# Altium schematic sheet PICO_D4_SD_CARD_T3.SchDoc
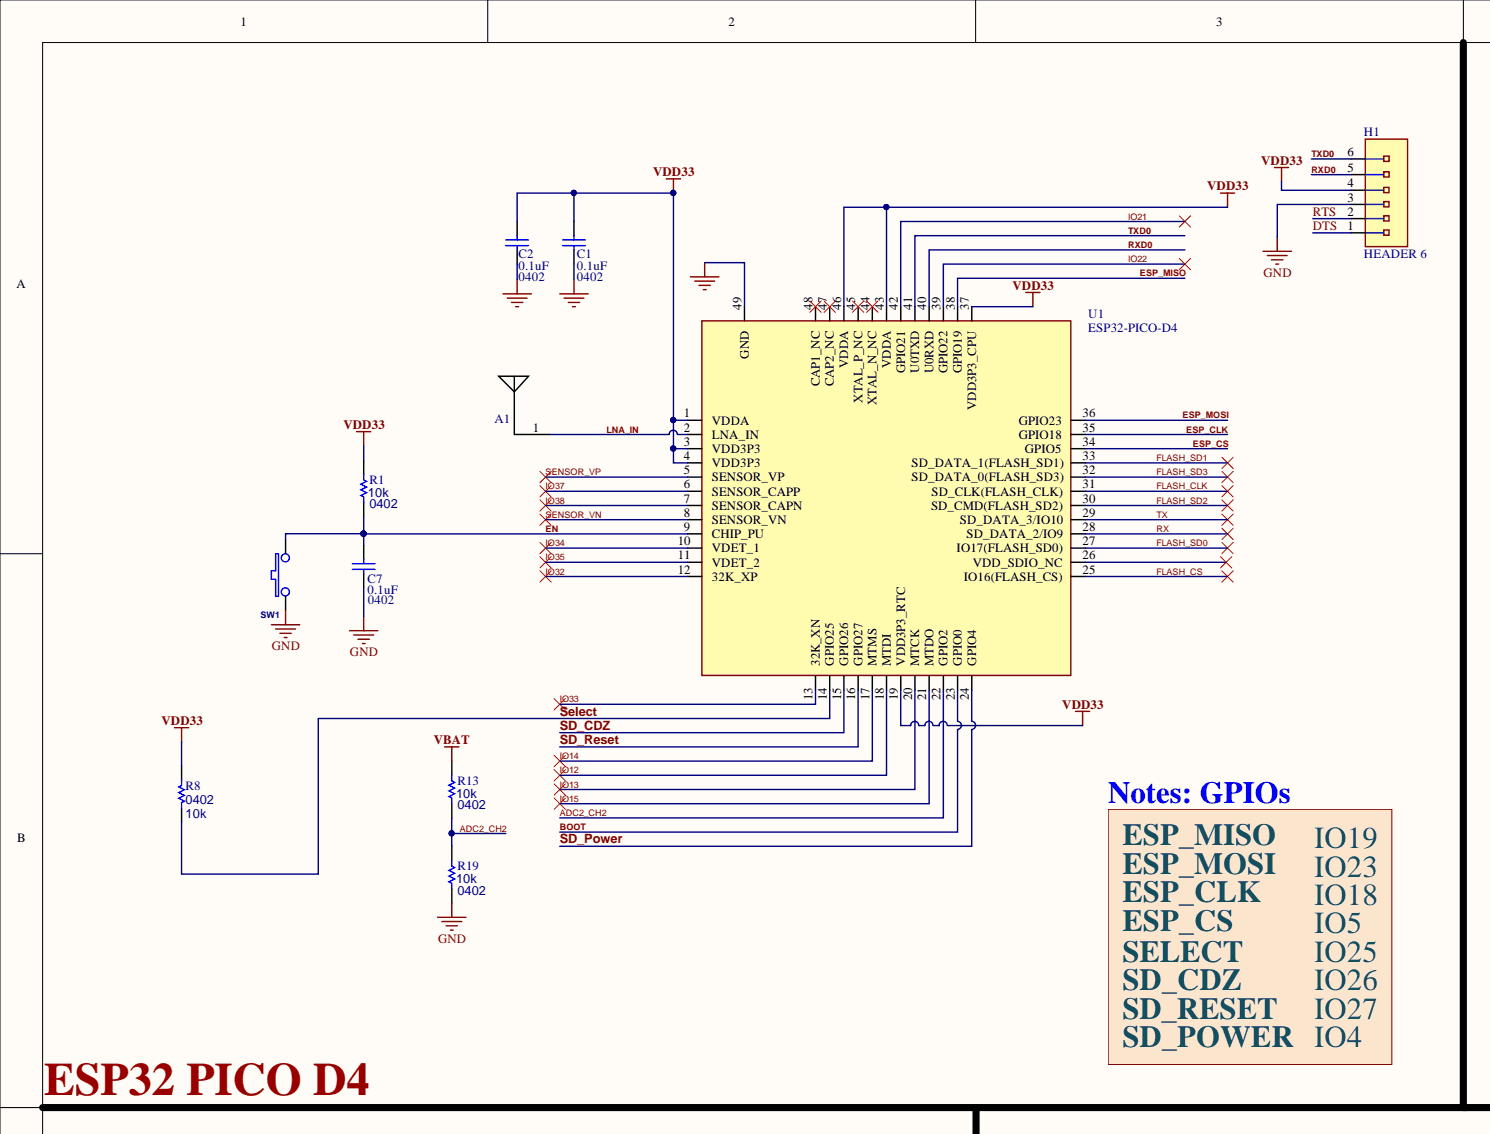
[diagram p0: PICO_D4_SD_CARD_T3.SchDoc, top left]
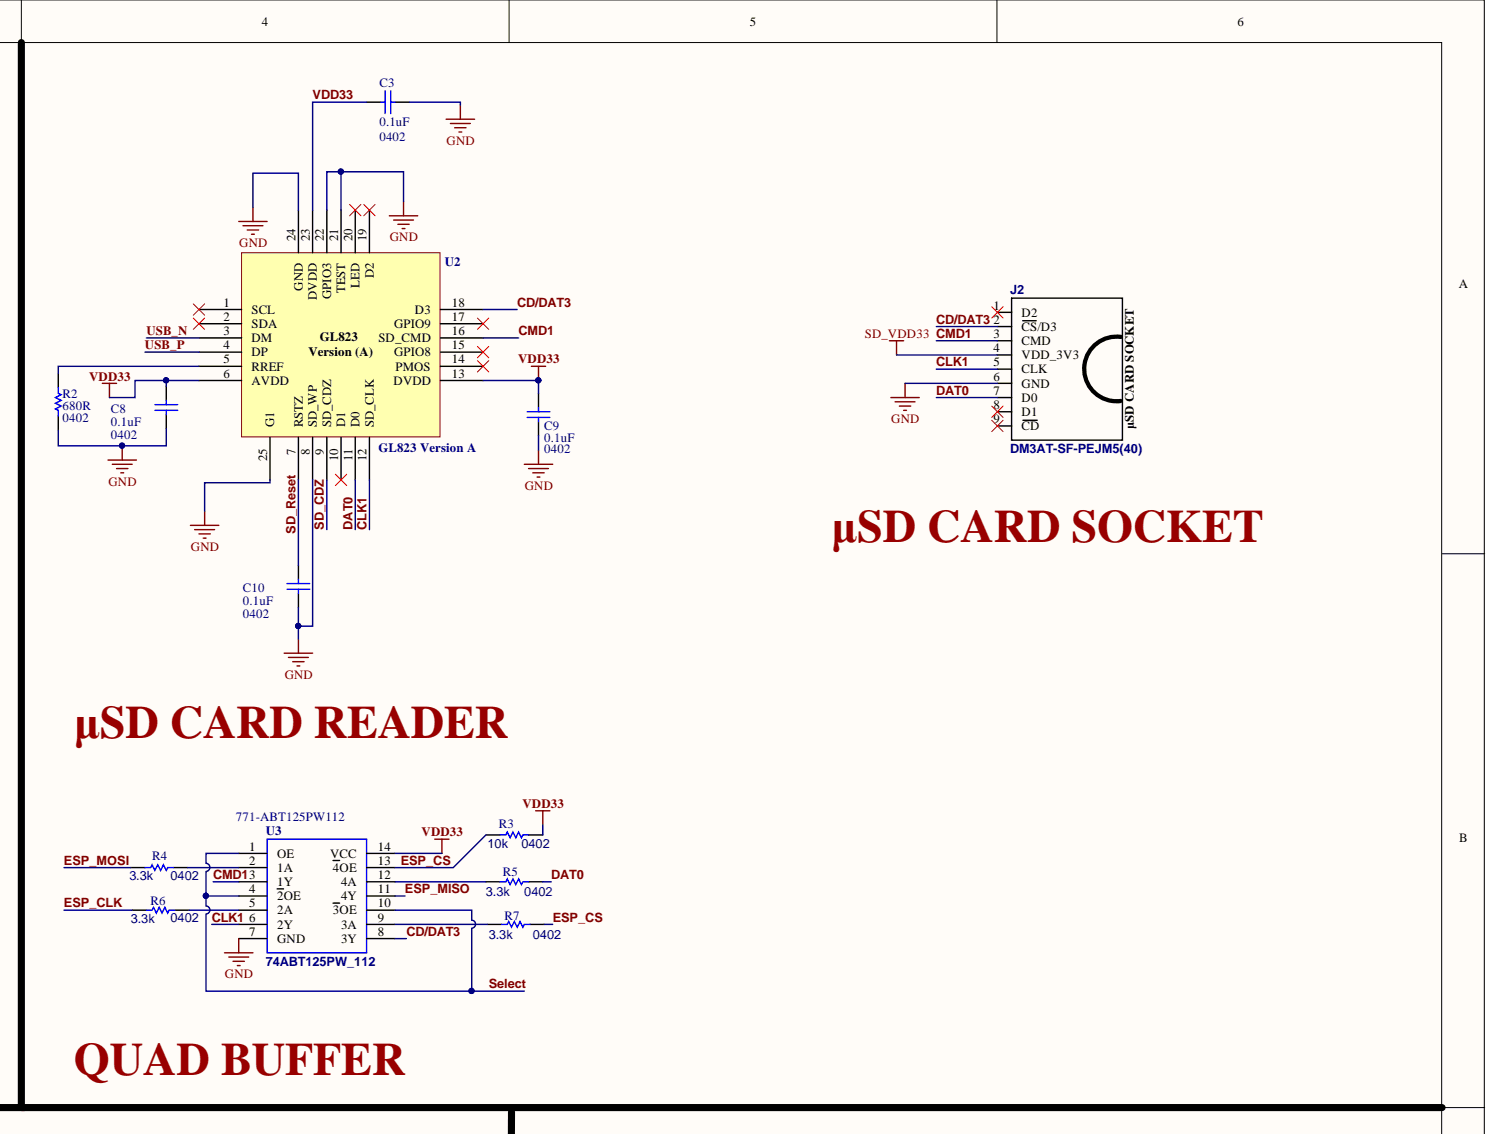
[diagram p1: PICO_D4_SD_CARD_T3.SchDoc, top right]
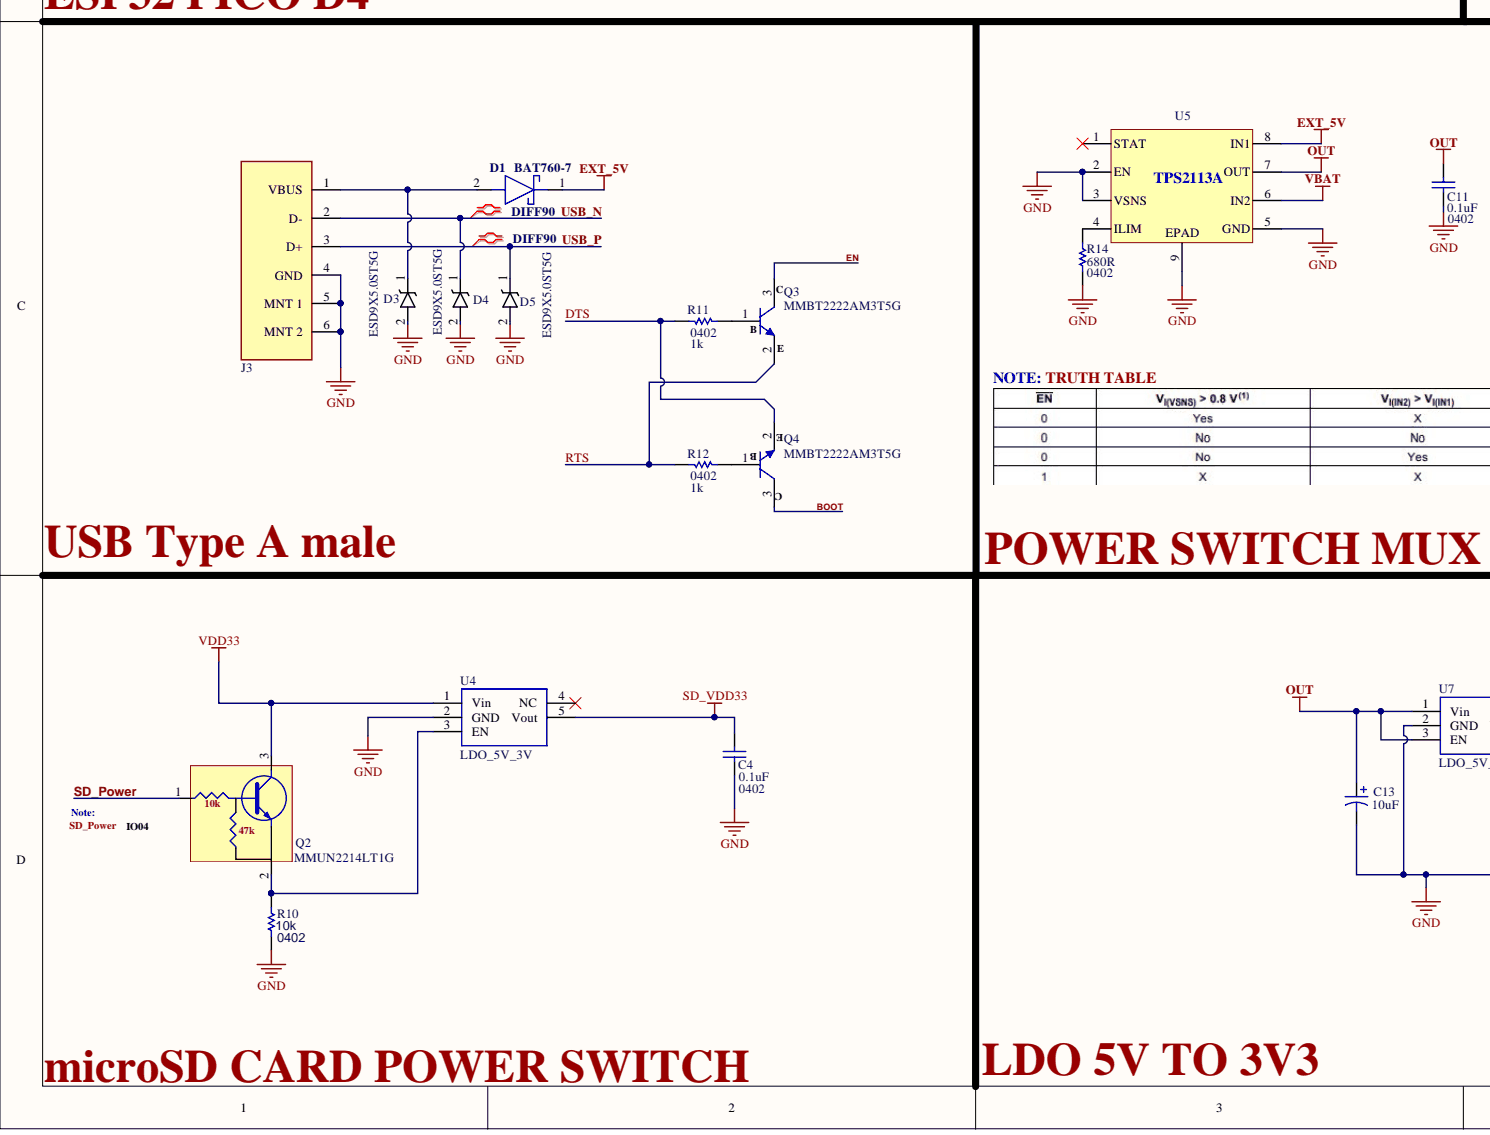
[diagram p2: PICO_D4_SD_CARD_T3.SchDoc, bottom left]
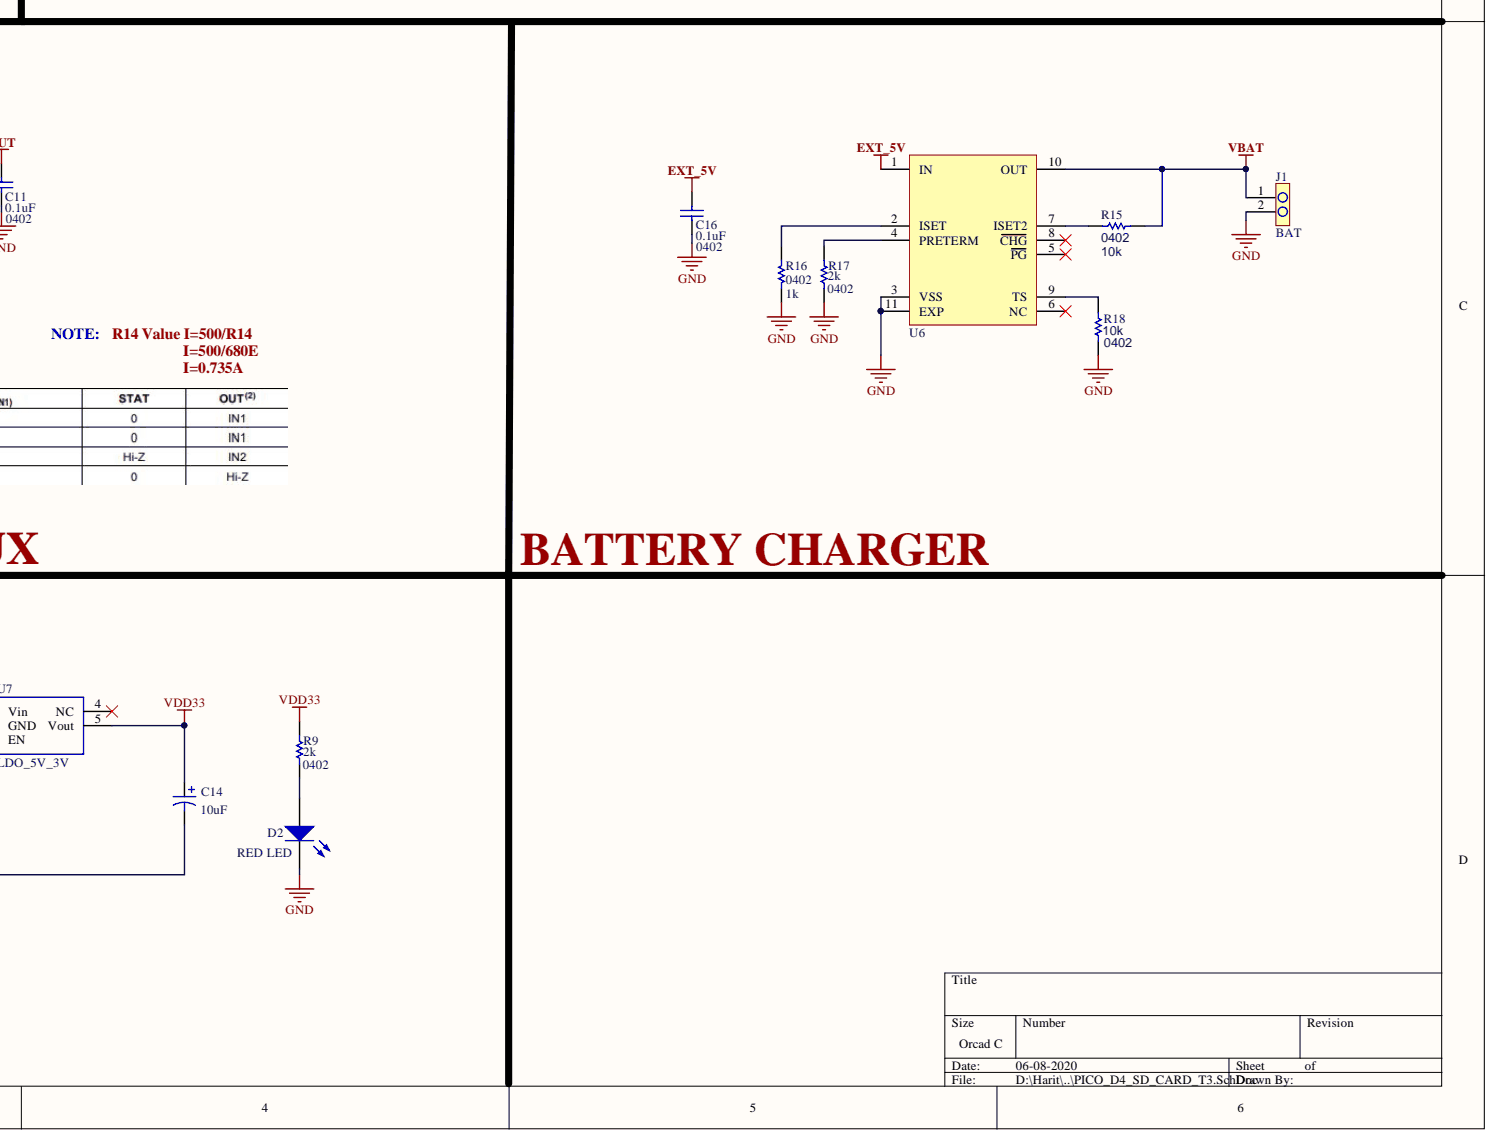
[diagram p3: PICO_D4_SD_CARD_T3.SchDoc, bottom right]

## Parts
A1  Ant  [ANT_2450AT43B100E]  (value not drawn)
C1  0.1uF  Case Code (Imperial): 0402  [CAPC0402]
C2  0.1uF  Case Code (Imperial): 0402  [CAPC0402]
C3  0.1uF  Case Code (Imperial): 0402  [CAPC0402]
C4  0.1uF  Case Code (Imperial): 0402  [CAPC0402]
C7  0.1uF  Case Code (Imperial): 0402  [CAPC0402]
C8  0.1uF  Case Code (Imperial): 0402  [CAPC0402]
C9  0.1uF  Case Code (Imperial): 0402  [CAPC0402]
C10  0.1uF  Case Code (Imperial): 0402  [CAPC0402]
C11  0.1uF  Case Code (Imperial): 0402  [CAPC0402]
C13  10uF  [CAPC0603]
C14  10uF  [CAPC0603]
C16  0.1uF  Case Code (Imperial): 0402  [CAPC0402]
D1  BAT760-7  [SOD2513X120N]
D2  RED LED  [LED_0603]
D3  ESD9X5.0ST5G  [SOD-923]
D4  ESD9X5.0ST5G  [SOD-923]
D5  ESD9X5.0ST5G  [SOD-923]
H1  HEADER 6  [HEADER_2MM]
J1  BAT  [HDR1X2H - duplicate]  (no BOM)
J2  DM3AT-SF-PEJM5(40)  [sd card connector]
J3  USB MALE  [Molex_48037-0001_0]  (value not drawn)
Q2  Manufacturer Part Number: MMUN2214LT1G  [SOT95P240X115-3N]  (hidden comment: Transistor NPN)
Q3  MMBT2222AM3T5G  [SOT-723]
Q4  MMBT2222AM3T5G  [SOT-723]
R1  value 10k  Case Code (Imperial): 0402  [resc0402]  (hidden comment: Res1)
R2  value 680R  Case Code (Imperial): 0402  [resc0402]
R3  value 10k  Case Code (Imperial): 0402  [resc0402]  (hidden comment: Res1)
R4  value 3.3k  Case/Package: 0402  [RESC0402]  (hidden comment: Res1)
R5  value 3.3k  Case/Package: 0402  [RESC0402]
R6  value 3.3k  Case/Package: 0402  [RESC0402]
R7  value 3.3k  Case/Package: 0402  [RESC0402]
R8  value 10k  Case Code (Imperial): 0402  [resc0402]  (hidden comment: Res1)
R9  value 2k  Case/Package: 0402  [resc0402]  (hidden comment: Res1)
R10  value 10k  Case/Package: 0402  [resc0402]  (hidden comment: Res1)
R11  value 1k  Case Code (Imperial): 0402  [resc0402]  (hidden comment: Res1)
R12  value 1k  Case Code (Imperial): 0402  [resc0402]  (hidden comment: Res1)
R13  value 10k  Case/Package: 0402  [resc0402]  (hidden comment: Res1)
R14  value 680R  Case Code (Imperial): 0402  [resc0402]
R15  value 10k  Case/Package: 0402  [resc0402]  (hidden comment: Res1)
R16  value 1k  Case Code (Imperial): 0402  [resc0402]  (hidden comment: Res1)
R17  value 2k  Case/Package: 0402  [resc0402]  (hidden comment: Res1)
R18  value 10k  Case/Package: 0402  [resc0402]  (hidden comment: Res1)
R19  value 10k  Case/Package: 0402  [resc0402]  (hidden comment: Res1)
SW1  SW PUSHBUTTON  [Micro_push]  (value not drawn)
U1  ESP32-PICO-D4  [ESP32_PICO_D4_2]
U2  GL823 Version A  [QFN24-4x4MM]
U3  74ABT125PW_112  Supplier Part Number 1: 771-ABT125PW112  [74ABT125PW]
U4  LDO_5V_3V  [SOT25]
U5  TPS2113ADRBR  [SON-8]  (value not drawn)
U6  BQ24040DSQR  [10-WFDFN]  (value not drawn)
U7  LDO_5V_3V  [SOT25]

## Nets
EXT_5V: C16.2 D1.1 U5.8(IN1) U6.1(IN)
GND: C1.2 C2.2 C3.1 C4.2 C7.1 C8.1 C9.1 C10.1 C11.1 C13.2 C14.2 C16.1 D2.2 D3.2 D4.2 D5.2 H1.3 J1.2 J2.6(GND) J3.4(GND) J3.5(MNT_1) J3.6(MNT_2) R2.2 R10.2 R14.2 R16.1 R17.2 R18.2 R19.2 SW1.1 U1.49(GND) U2.8(SD_WP) U2.21(TEST) U2.22(GPIO3) U2.24(GND) U2.25(G1) U3.7(GND) U4.2(GND) U5.2(EN) U5.3(VSNS) U5.5(GND) U5.9 U6.3(VSS) U6.11(EXP) U7.2(GND)
OUT: C11.2 C13.1 U5.7(OUT) U7.1(Vin) U7.3(EN)
SD_VDD33: C4.1 J2.4(VDD_3V3) U4.5(Vout)
VBAT: J1.1 R13.1 R15.2 U5.6(IN2) U6.10(OUT)
ADC2_CH2: R13.2 R19.1 U1.22(GPIO2)
BOOT: Q4.3 U1.23(GPIO0)
CD/DAT3: J2.2(CS/D3) U2.18(D3) U3.8(3Y)
CLK1: J2.5(CLK) U2.12(SD_CLK) U3.6(2Y)
CMD1: J2.3(CMD) U2.16(SD_CMD) U3.3(1Y)
DAT0: J2.7(D0) R5.1 U2.11(D0)
DTS: H1.1 Q4.2 R11.1
EN: C7.2 Q3.3 R1.2 SW1.2 U1.9(CHIP_PU)
ESP_CLK: R6.2 U1.35(GPIO18)
ESP_CS: R3.2 R7.1 U1.34(GPIO5) U3.13(4OE)
ESP_MISO: U1.38(GPIO19) U3.11(4Y)
ESP_MOSI: R4.2 U1.36(GPIO23)
FLASH_CLK: U1.31(SD_CLK(FLASH_CLK))
FLASH_CS: U1.25(IO16(FLASH_CS))
FLASH_SD0: U1.27(IO17(FLASH_SD0))
FLASH_SD1: U1.33(SD_DATA_1(FLASH_SD1))
FLASH_SD2: U1.30(SD_CMD(FLASH_SD2))
FLASH_SD3: U1.32(SD_DATA_0(FLASH_SD3))
IO12: U1.18(MTDI)
IO13: U1.20(MTCK)
IO14: U1.17(MTMS)
IO15: U1.21(MTDO)
IO21: U1.42(GPIO21)
IO22: U1.39(GPIO22)
IO32: U1.12(32K_XP)
IO33: U1.13(32K_XN)
IO34: U1.10(VDET_1)
IO35: U1.11(VDET_2)
IO37: U1.6(SENSOR_CAPP)
IO38: U1.7(SENSOR_CAPN)
LNA_IN: A1.1 U1.2(LNA_IN)
RTS: H1.2 Q3.2 R12.1
RX: U1.28(SD_DATA_2/IO9)
RXD0: H1.5 U1.40(U0RXD)
SD_CDZ: U1.15(GPIO26) U2.9(SD_CDZ)
SD_Power: Q2.1 U1.24(GPIO4)
SD_Reset: C10.2 U1.16(GPIO27) U2.7(RSTZ)
Select: R8.2 U1.14(GPIO25) U3.1(OE) U3.4(2OE) U3.10(3OE)
SENSOR_VN: U1.8(SENSOR_VN)
SENSOR_VP: U1.5(SENSOR_VP)
TX: U1.29(SD_DATA_3/IO10)
TXD0: H1.6 U1.41(U0TXD)
USB_N: D4.1 J3.2(D-) U2.3(DM)
USB_P: D5.1 J3.3(D+) U2.4(DP)
VDD33: C1.1 C2.1 C3.2 C8.2 C9.2 C14.1 H1.4 Q2.3 R1.1 R3.1 R8.1 R9.1 U1.1(VDDA) U1.3(VDD3P3) U1.4(VDD3P3) U1.19(VDD3P3_RTC) U1.37(VDD3P3_CPU) U1.43(VDDA) U1.46(VDDA) U2.6(AVDD) U2.13(DVDD) U2.23(DVDD) U3.14(VCC) U4.1(Vin) U7.5(Vout)
NetD1_2: D1.2 D3.1 J3.1(VBUS)
NetD2_1: D2.1 R9.2
NetQ2_2: Q2.2 R10.1 U4.3(EN)
NetQ3_1: Q3.1 R11.2
NetQ4_1: Q4.1 R12.2
NetR2_1: R2.1 U2.5(RREF)
NetR4_1: R4.1 U3.2(1A)
NetR5_2: R5.2 U3.12(4A)
NetR6_1: R6.1 U3.5(2A)
NetR7_2: R7.2 U3.9(3A)
NetR14_1: R14.1 U5.4(ILIM)
NetR15_1: R15.1 U6.7(ISET2)
NetR16_2: R16.2 U6.2(ISET)
NetR17_1: R17.1 U6.4(PRETERM)
NetR18_1: R18.1 U6.9(TS)

Not connected: J2 1(D2) 8(D1) 9(CD); U1 26(VDD_SDIO_NC) 44(XTAL_N_NC) 45(XTAL_P_NC) 47(CAP2_NC) 48(CAP1_NC); U2 1(SCL) 2(SDA) 10(D1) 14(PMOS) 15(GPIO8) 17(GPIO9) 19(D2) 20(LED); U4 4(NC); U5 1(STAT); U6 5(PG) 6(NC) 8(CHG); U7 4(NC)

## Library data of the parts
A1 = Ant: High Frequency Ceramic Solutions 4-Pin SMD T/R; Manufacturer: Johanson; Manufacturer Part Number: 2450AT43B100E; Frequency: 2.4GHz; Gain: -0.5dB; Impedance: 50R; Max Frequency: 2.5GHz; Max Power Dissipation: 2W; Number of Bands: 1; Return Loss: -9.5dB; Case/Package: SMD/SMT; Category: RF Antennas; Component Kind: Standard; ComponentLink1Description: https://datasheet.ciiva.com/24068/2450at43b100-242468-24068034.pdf?src-supplier=Mouser; ComponentLink1URL: https://datasheet.ciiva.com/24068/2450at43b100-242468-24068034.pdf?src-supplier=Mouser; ComponentLink2Description: https://datasheet.ciiva.com/2792/jti-antenna-2450at43b100-09042008-2792593.pdf?src-supplier=Digi-Key; ComponentLink2URL: https://datasheet.ciiva.com/2792/jti-antenna-2450at43b100-09042008-2792593.pdf?src-supplier=Digi-Key; ComponentLink3Description: https://datasheet.ciiva.com/7633/1860765-7633973.pdf?src-supplier=Element14; ComponentLink3URL: https://datasheet.ciiva.com/7633/1860765-7633973.pdf?src-supplier=Element14; Contact Plating: Tin; Height: 0.079inch; Length: 7mm; Library Name: CUSTOM TNY290PG.SchLib; Mount: Surface Mount; Number of Pins: 4; Package Quantity: 1; Packaging: Tape and Reel; Power Rating: 2W; Pricing 1: 1=0.71000, 10=0.62400, 25=0.58600, 100=0.47820, 250=0.44416, 500=0.37800 (USD); Radiation Hardening: No; REACH SVHC: Unknown; RoHS: Yes; Stock 1: 63050; Supplier 1: Digi-Key; Supplier Part Number 1: 712-1010-1-ND; Termination: Solder; Width: 2mm
C1-C4 C7-C11 C16 = Cap: Ceramic Capacitor,100nF,16V,0402; Manufacturer: TDK; Manufacturer Part Number: C1608X7R1C104K; Mfr.: C0805C104K1RECAUTO; Dielectric: X7R; Tolerance: 10%; Capacitance: 100nF; Case Code (Metric): 1608; Case/Package: 0402; Component Kind: Standard; ComponentLink1Description: https://media.digikey.com/pdf/Data%20Sheets/TDK%20PDFs/C_Series_GeneralAppl_Spec_rev_0.3_201012.pdf?src-supplier=Digi-Key; ComponentLink1URL: https://media.digikey.com/pdf/Data%20Sheets/TDK%20PDFs/C_Series_GeneralAppl_Spec_rev_0.3_201012.pdf?src-supplier=Digi-Key; ComponentLink2Description: https://media.digikey.com/pdf/Data%20Sheets/TDK%20PDFs/C_Series_GeneralAppl_B11.pdf?src-supplier=Digi-Key; ComponentLink2URL: https://media.digikey.com/pdf/Data%20Sheets/TDK%20PDFs/C_Series_GeneralAppl_B11.pdf?src-supplier=Digi-Key; ComponentLink3Description: https://www.verical.com/server-webapp/html/getDatasheet?partID=169336&src-supplier=Verical; ComponentLink3URL: https://www.verical.com/server-webapp/html/getDatasheet?partID=169336&src-supplier=Verical; ComponentLink4Description: http://www.tdk.co.jp/tefe02/e412_c.pdf?src-supplier=Future+Electronics; ComponentLink4URL: http://www.tdk.co.jp/tefe02/e412_c.pdf?src-supplier=Future+Electronics; ComponentLink5Description: http://www.farnell.com/datasheets/1571323.pdf?src-supplier=Element14; ComponentLink5URL: http://www.farnell.com/datasheets/1571323.pdf?src-supplier=Element14; Composition: Ceramic; Depth: 0.8mm; Dielectric Material: Ceramic; Height: 0.8mm; Lead Free: Lead Free; Length: 1.6mm; Library Name: Miscellaneous Devices.IntLib; Max Operating Temperature: 125°C; Min Operating Temperature: -55°C; Mount: Surface Mount; Number of Pins: 2; Package Quantity: 1; Packaging: Tape and Reel; Radiation Hardening: No; Stock 1: 0; Supplier 1: Digi-Key; Supplier Part Number 1: 445-1317-6-ND; Termination: SMD/SMT; Thickness: 0.037inch; Value: 0.1uF; Voltage Rating: 16V; Voltage Rating (DC): 16V; Width: 0.8mm
C13 C14 = Cap Pol2: Ceramic Capacitor,10uF,16V,0603; Mfr. No: 0603C106KAT2A; Case/Package: 0603; Component Kind: Standard; Library Name: Miscellaneous Devices.SchLib; Value: 10uF
D1 = BAT760-7: Schottky Diodes & Rectifiers 1A 30V LF1A, 2-Pin SOD-323; Case/Package: SOD-323; Component Kind: Standard; Library Name: CUSTOM TNY290PG.SchLib; Manufacturer Part Number: BAT760-7
D2 = LED1: LED, RED,SMD, 0603; Case/Package: 0603; Component Kind: Standard; Library Name: Miscellaneous Devices.SchLib; Manufacturer Part Number: LED, RED,SMD, 0603
D3-D5 = ESD9X5.0ST5G: 12.3V Clamp 8.7A (8/20µs) Ipp Tvs Diode Surface Mount SOD-923; Manufacturer: ON Semiconductor; Breakdown Voltage: 6.2V; Capacitance: 65pF; Direction: Unidirectional; Element Configuration: Single; ESD Protection: Yes; Leakage Current: 1uA; Max Power Dissipation: 150mW; Number of Channels: 1; Operating Supply Voltage: 5V; Peak Pulse Power: 107W; Power Line Protection: No; Test Current: 1mA; Case/Package: SOD-923-2; Category: ESD and Circuit Protection ICs; Clamping Voltage: 12.3V; Component Kind: Standard; ComponentLink1Description: https://datasheet.ciiva.com/30478/0900766b812769b5-30478676.pdf?src-supplier=RSComponents; ComponentLink1URL: https://datasheet.ciiva.com/30478/0900766b812769b5-30478676.pdf?src-supplier=RSComponents; ComponentLink2Description: https://datasheet.ciiva.com/25958/esd9x3-3st5g-d-25958458.pdf?src-supplier=Avnet+Europe; ComponentLink2URL: https://datasheet.ciiva.com/25958/esd9x3-3st5g-d-25958458.pdf?src-supplier=Avnet+Europe; ComponentLink3Description: https://datasheet.ciiva.com/1219/1748783-1219324.pdf?src-supplier=Newark; ComponentLink3URL: https://datasheet.ciiva.com/1219/1748783-1219324.pdf?src-supplier=Newark; ComponentLink4Description: https://datasheet.ciiva.com/3304/esd9x3-3st5g-d-3304012.pdf?src-supplier=Avnet; ComponentLink4URL: https://datasheet.ciiva.com/3304/esd9x3-3st5g-d-3304012.pdf?src-supplier=Avnet; ComponentLink5Description: https://datasheet.ciiva.com/339/esd9x3-3st5g-d-339709.pdf?src-supplier=Digi-Key; ComponentLink5URL: https://datasheet.ciiva.com/339/esd9x3-3st5g-d-339709.pdf?src-supplier=Digi-Key; ComponentLink6Description: https://datasheet.ciiva.com/16215/esd9x3-3st5g-d-16215149.pdf?src-supplier=Future+Electronics; ComponentLink6URL: https://datasheet.ciiva.com/16215/esd9x3-3st5g-d-16215149.pdf?src-supplier=Future+Electronics; ComponentLink7Description: https://datasheet.ciiva.com/339/esd9x3-3st5g-d-339710.pdf?src-supplier=Digi-Key; ComponentLink7URL: https://datasheet.ciiva.com/339/esd9x3-3st5g-d-339710.pdf?src-supplier=Digi-Key; ComponentLink8Description: https://datasheet.ciiva.com/339/esd9x3-3st5g-d-339711.pdf?src-supplier=Digi-Key; ComponentLink8URL: https://datasheet.ciiva.com/339/esd9x3-3st5g-d-339711.pdf?src-supplier=Digi-Key; Composition: Zener; Contact Plating: Tin,Matte; Depth: 0.6mm; Halogen Free: Halogen Free; Height: 0.4mm; Lead Free: Lead Free; Length: 0.8mm; Library Name: CUSTOM TNY290PG.SchLib; Manufacturer Part Number: ESD9X5.0ST5G; Max Breakdown Voltage: 6.2V; Max Operating Temperature: 150°C; Max Reverse Leakage Current: 1uA; Max Surge Current: 8.7A; Min Breakdown Voltage: 6.2V; Min Operating Temperature: -55°C; Number of Elements: 1; Number of Lines: 1; Number of Pins: 2; Number of Unidirectional Channels: 1; Package Quantity: 1; Packaging: Tape and Reel; Peak Pulse Current: 8.7A; Polarity: Unidirectional; Pricing 1: 1=0.14000, 10=0.12700, 25=0.12120, 100=0.05340, 250=0.05120, 500=0.04190, 1000=0.02910, 2500=0.02542 (USD); Radiation Hardening: No; REACH SVHC: No SVHC; Reverse Breakdown Voltage: 6.2V; Reverse Standoff Voltage: 5V; RoHS: Yes; Stock 1: 835133; Supplier 1: Digi-Key; Supplier Part Number 1: ESD9X5.0ST5GOSCT-ND; Termination: SMD/SMT; Width: 0.65mm; Working Voltage: 5V
H1 = HEADER 6: Header, 6-Pin,2MM; Manufacturer Part Number: 2mm PITCH; Case/Package: TH; Component Kind: Standard; Library Name: CUSTOM TNY290PG.SchLib
J1 = Header 2 pin: Library Name: CUSTOM TNY290PG.SchLib
J2 = DM3AT-SF-PEJM5(40): Micro SD Memory Card Socket; Manufacturer_Name: Hirose; Allied_Number: R1050628; Allied Price/Stock: http://www.alliedelec.com/hirose-electric-dm3at-sf-pejm5-40-/R1050628/; Component Kind: Standard; Datasheet Link: http://uk.rs-online.com/web/p/products/7079520; Library Name: CUSTOM TNY290PG.SchLib; Manufacturer Part Number: DM3AT-SF-PEJM5(40); Mouser Part Number: 798-DM3AT-SF-PEJM540; Mouser Price/Stock: https://www.mouser.com/Search/Refine.aspx?Keyword=798-DM3AT-SF-PEJM540; RS Part Number: 7079520P; RS Price/Stock: http://uk.rs-online.com/web/p/products/7079520P
J3 = USB MALE: USB A-type Plug Male PCB R/A; Manufacturer Part Number: USB Type A male; Case/Package: TH; Component Kind: Standard; Library Name: CUSTOM TNY290PG.SchLib
Q2 = Transistor NPN: Bipolar Transistors - Pre-Biased 100mA 50V BRT NPN; Manufacturer: ON Semiconductor; Collector Emitter Saturation Voltage: 250mV; Element Configuration: Single; hFE Min: 80; Max Power Dissipation: 246mW; Case/Package: SOT-23-3; Collector Emitter Breakdown Voltage: 50V; Collector Emitter Voltage (VCEO): 50V; Component Kind: Standard; ComponentLink1Description: http://www.onsemi.ru.com/pub/Collateral/DTC114Y-D.PDF?src-supplier=Avnet+Europe; ComponentLink1URL: http://www.onsemi.ru.com/pub/Collateral/DTC114Y-D.PDF?src-supplier=Avnet+Europe; ComponentLink2Description: https://www.verical.com/server-webapp/html/getDatasheet?partID=38473&src-supplier=Verical; ComponentLink2URL: https://www.verical.com/server-webapp/html/getDatasheet?partID=38473&src-supplier=Verical; ComponentLink3Description: http://www.onsemi.com/pub/Collateral/DTC114Y-D.PDF?src-supplier=Digi-Key; ComponentLink3URL: http://www.onsemi.com/pub/Collateral/DTC114Y-D.PDF?src-supplier=Digi-Key; ComponentLink4Description: http://www.onsemi.com/pub/Collateral/DTC114Y-D.PDF?src-supplier=Future+Electronics; ComponentLink4URL: http://www.onsemi.com/pub/Collateral/DTC114Y-D.PDF?src-supplier=Future+Electronics; Contact Plating: Tin,Matte; Continuous Collector Current: 100mA; Current Rating: 100mA; Halogen Free: Halogen Free; Height: 0.94mm; Lead Free: Lead Free; Length: 2.9mm; Library Name: CUSTOM TNY290PG.SchLib; Max Breakdown Voltage: 50V; Max Collector Current: 100mA; Max Operating Temperature: 150°C; Max Output Current: 100mA; Min Operating Temperature: -55°C; Number of Pins: 3; Operating Supply Voltage: 50V; Package Quantity: 1; Packaging: Tape and Reel; Polarity: NPN; Power Dissipation: 246mW; Pricing 1: 1=0.12, 10=0.105, 50=0.105, 100=0.037, 1000=0.025, 10000=0.017 (USD); Radiation Hardening: No; REACH SVHC: No SVHC; Stock 1: 56410; Supplier 1: Mouser; Supplier Part Number 1: 863-MMUN2214LT1G; Voltage Rating (DC): 50V; Width: 1.3mm
Q3 Q4 = MMBT2222AM3T5G: Bipolar Transistors - BJT SS SOT-723 GP TRANSISTOR NPN; Manufacturer: ON Semiconductor; Collector Base Voltage (VCBO): 75V; Collector Emitter Breakdown Voltage: 40V; Element Configuration: Single; Emitter Base Voltage (VEBO): 6V; Frequency: 300MHz; Max Collector Current: 600mA; Max Power Dissipation: 640mW; Number of Elements: 1; Case/Package: SOT-723-3; Collector Emitter Voltage (VCEO): 40V; Component Kind: Standard; ComponentLink1Description: Manufacturer Link; ComponentLink1URL: http://www.fairchildsemi.com/; ComponentLink2Description: Datasheet; ComponentLink2URL: http://www.fairchildsemi.com/ds/2N%2F2N3904.pdf; ComponentLink3Description: http://www.mouser.com/ds/2/308/MMBT2222AM3-D-107843.pdf?src-supplier=Mouser; ComponentLink3URL: http://www.mouser.com/ds/2/308/MMBT2222AM3-D-107843.pdf?src-supplier=Mouser; ComponentLink4Description: https://4donline.ihs.com/images/VipMasterIC/IC/ONSM/ONSM-S-A0003065668/ONSM-S-A0003065668-1.pdf?hkey=52A5661711E402568146F3353EA87419&src-supplier=Element14; ComponentLink4URL: https://4donline.ihs.com/images/VipMasterIC/IC/ONSM/ONSM-S-A0003065668/ONSM-S-A0003065668-1.pdf?hkey=52A5661711E402568146F3353EA87419&src-supplier=Element14; ComponentLink5Description: http://www.onsemi.com/pub/Collateral/MMBT2222AM3-D.PDF?src-supplier=Avnet; ComponentLink5URL: http://www.onsemi.com/pub/Collateral/MMBT2222AM3-D.PDF?src-supplier=Avnet; ComponentLink6Description: http://www.onsemi.com/pub/Collateral/MMBT2222AM3-D.PDF?src-supplier=Digi-Key; ComponentLink6URL: http://www.onsemi.com/pub/Collateral/MMBT2222AM3-D.PDF?src-supplier=Digi-Key; ComponentLink7Description: https://www.verical.com/server-webapp/html/getDatasheet?partID=185710&src-supplier=Verical; ComponentLink7URL: https://www.verical.com/server-webapp/html/getDatasheet?partID=185710&src-supplier=Verical; ComponentLink8Description: http://www.onsemi.com/pub/Collateral/MMBT2222AM3-D.PDF?src-supplier=Avnet+Europe; ComponentLink8URL: http://www.onsemi.com/pub/Collateral/MMBT2222AM3-D.PDF?src-supplier=Avnet+Europe; ComponentLink9Description: http://www.onsemi.com/pub_link/Collateral/MMBT2222AM3-D.PDF?src-supplier=Future+Electronics; ComponentLink9URL: http://www.onsemi.com/pub_link/Collateral/MMBT2222AM3-D.PDF?src-supplier=Future+Electronics; Contact Plating: Tin,Matte; Gain Bandwidth Product: 300MHz; Lead Free: Lead Free; Library Name: CUSTOM TNY290PG.SchLib; Manufacturer Part Number: MMBT2222AM3T5G; Max Breakdown Voltage: 40V; Max Frequency: 300MHz; Max Operating Temperature: 150°C; Min Operating Temperature: -55°C; Number of Pins: 3; Package Quantity: 1; Packaging: Tape and Reel; Polarity: NPN; Power Dissipation: 640mW; Pricing 1: 1=0.2, 10=0.135, 50=0.135, 100=0.057, 1000=0.039, 2500=0.03, 8000=0.026, 10000=0.026, 24000=0.024, 48000=0.023 (USD); Radiation Hardening: No; REACH SVHC: No SVHC; Signal Integrity: 2N3904; Simulation: 2N3904; Stock 1: 18115; Supplier 1: Mouser; Supplier Part Number 1: 863-MMBT2222AM3T5G; Transition Frequency: 300MHz
R1 R3 R8 R10 R13 R15 R18 R19 = Res1: Thick Film Resistor -  10Kohm, 1%, 0402; Manufacturer: Vishay; Manufacturer Part Number: CRCW040210K0FKED; Max Power Dissipation: 63mW; Temperature Coefficient: 100ppm/°C; Voltage Rating: 50V; Component Kind: Standard; ComponentLink1Description: http://uk.rs-online.com/webdocs/0d98/0900766b80d98824.pdf?src-supplier=RSComponents; ComponentLink1URL: http://uk.rs-online.com/webdocs/0d98/0900766b80d98824.pdf?src-supplier=RSComponents; ComponentLink2Description: http://www.vishay.com/docs/20035/dcrcwe3.pdf?src-supplier=Digi-Key; ComponentLink2URL: http://www.vishay.com/docs/20035/dcrcwe3.pdf?src-supplier=Digi-Key; ComponentLink3Description: http://www.farnell.com/datasheets/2045673.pdf?src-supplier=Element14; ComponentLink3URL: http://www.farnell.com/datasheets/2045673.pdf?src-supplier=Element14; ComponentLink4Description: http://www.vishay.com/docs/20035/dcrcwe3.pdf?src-supplier=Avnet; ComponentLink4URL: http://www.vishay.com/docs/20035/dcrcwe3.pdf?src-supplier=Avnet; ComponentLink5Description: https://www.distrelec.biz/Web/Downloads/a_/en/flD-CRCW-e3_data_en.pdf?mime=application%2Fpdf&src-supplier=Distrelec; ComponentLink5URL: https://www.distrelec.biz/Web/Downloads/a_/en/flD-CRCW-e3_data_en.pdf?mime=application%2Fpdf&src-supplier=Distrelec; ComponentLink6Description: http://www.vishay.com/docs/20035/dcrcwe3.pdf?src-supplier=Mouser; ComponentLink6URL: http://www.vishay.com/docs/20035/dcrcwe3.pdf?src-supplier=Mouser; ComponentLink7Description: http://www.farnell.com/datasheets/2111203.pdf?src-supplier=Element14; ComponentLink7URL: http://www.farnell.com/datasheets/2111203.pdf?src-supplier=Element14; ComponentLink8Description: http://www.vishay.com/docs/20035/dcrcwe3.pdf?src-supplier=Future+Electronics; ComponentLink8URL: http://www.vishay.com/docs/20035/dcrcwe3.pdf?src-supplier=Future+Electronics; Composition: Thick Film; Contact Plating: Tin; Depth: 0.5mm; Features: Automotive AEC-Q200; Height: 0.35mm; Lead Free: Lead Free; Length: 1mm; Library Name: Miscellaneous Devices.IntLib; Max Operating Temperature: 155°C; Military Standard: Not; Min Operating Temperature: -55°C; Mount: Surface Mount; Number of Pins: 2; Number of Terminations: 2; Package Quantity: 10; Packaging: Tape and Reel; Power Rating: 63mW; Pricing 1: 1=0.1, 10=0.042, 50=0.042, 100=0.029, 1000=0.016, 10000=0.011 (USD); Radiation Hardening: No; REACH SVHC: Unknown; Stock 1: 7743; Supplier 1: Mouser; Supplier Part Number 1: 71-CRCW0402-10K-E3; Termination: SMD/SMT; Tolerance: 1%; Voltage Rating (DC): 50V; Weight: 2.3E-05oz; Width: 0.02inch
  R1 R3 R8: Case Code (Metric): 1005; Case/Package: 0402
R2 R14 = Res1: Thick Film Resistor - 680 ohm, 1%, 0402; Manufacturer: Panasonic; Manufacturer Part Number: ERA-2AEB681X; Max Power Dissipation: 63mW; Temperature Coefficient: 25ppm/°C; Tolerance: 0.1%; Voltage Rating: 25V; Case Code (Metric): 1005; Case/Package: 0402; Component Kind: Standard; ComponentLink10Description: http://uk.rs-online.com/webdocs/0bee/0900766b80beefcc.pdf?src-supplier=RSComponents; ComponentLink10URL: http://uk.rs-online.com/webdocs/0bee/0900766b80beefcc.pdf?src-supplier=RSComponents; ComponentLink11Description: http://industrial.panasonic.com/www-data/pdf/AOA0000/AOA0000CE26.pdf?src-supplier=Avnet+Europe; ComponentLink11URL: http://industrial.panasonic.com/www-data/pdf/AOA0000/AOA0000CE26.pdf?src-supplier=Avnet+Europe; ComponentLink1Description: http://www.farnell.com/datasheets/1678796.pdf?src-supplier=Element14; ComponentLink1URL: http://www.farnell.com/datasheets/1678796.pdf?src-supplier=Element14; ComponentLink2Description: http://www.farnell.com/datasheets/2059623.pdf?src-supplier=Element14; ComponentLink2URL: http://www.farnell.com/datasheets/2059623.pdf?src-supplier=Element14; ComponentLink3Description: http://industrial.panasonic.com/www-cgi/jvcr13pz.cgi?E+PZ+3+AOA0002+ERJ1GNJ120C+7+WW?src-supplier=Mouser; ComponentLink3URL: http://industrial.panasonic.com/www-cgi/jvcr13pz.cgi?E+PZ+3+AOA0002+ERJ1GNJ120C+7+WW?src-supplier=Mouser; ComponentLink4Description: http://industrial.panasonic.com/www-data/pdf/AOA0000/AOA0000CE1.pdf?src-supplier=Avnet+Europe; ComponentLink4URL: http://industrial.panasonic.com/www-data/pdf/AOA0000/AOA0000CE1.pdf?src-supplier=Avnet+Europe; ComponentLink5Description: http://www.jameco.com/Jameco/Products/ProdDS/2007265.pdf?src-supplier=Jameco; ComponentLink5URL: http://www.jameco.com/Jameco/Products/ProdDS/2007265.pdf?src-supplier=Jameco; ComponentLink6Description: http://industrial.panasonic.com/www-data/pdf/AOA0000/AOA0000CE1.pdf?src-supplier=Avnet; ComponentLink6URL: http://industrial.panasonic.com/www-data/pdf/AOA0000/AOA0000CE1.pdf?src-supplier=Avnet; ComponentLink7Description: https://industrial.panasonic.com/cdbs/www-data/pdf/RDA0000/AOA0000C301.pdf?src-supplier=Future+Electronics; ComponentLink7URL: https://industrial.panasonic.com/cdbs/www-data/pdf/RDA0000/AOA0000C301.pdf?src-supplier=Future+Electronics; ComponentLink8Description: http://www.mouser.com/ds/2/315/AOA0000CE26-197354.pdf?src-supplier=Mouser; ComponentLink8URL: http://www.mouser.com/ds/2/315/AOA0000CE26-197354.pdf?src-supplier=Mouser; ComponentLink9Description: http://www.farnell.com/datasheets/328837.pdf?src-supplier=Element14; ComponentLink9URL: http://www.farnell.com/datasheets/328837.pdf?src-supplier=Element14; Composition: Thin Film,Metal Film; Contact Plating: Tin; Depth: 0.5mm; Features: Automotive AEC-Q200; Height: 0.35mm; Lead Free: Lead Free; Length: 1mm; Library Name: Miscellaneous Devices.IntLib; Max Operating Temperature: 155°C; Military Standard: Not; Min Operating Temperature: -55°C; Mount: Surface Mount; Number of Pins: 2; Number of Terminations: 2; Package Quantity: 1; Packaging: Tape and Reel; Power Rating: 63mW; Pricing 1: 1=0.59, 10=0.585, 50=0.585, 100=0.162, 1000=0.104, 10000=0.057 (USD); Radiation Hardening: No; REACH SVHC: No SVHC; Stock 1: 13785; Supplier 1: Mouser; Supplier Part Number 1: 667-ERA-2AEB681X; Termination: SMD/SMT; Voltage Rating (DC): 25V; Width: 0.02inch
R4-R7 = Res1: Thick Film Resistor - 3.3 Kohm, 1%, 0402; Manufacturer: Vishay Dale; Manufacturer Part Number: CRCW04023K30JNED; Max Power Dissipation: 63mW; Temperature Coefficient: 200ppm/°C; Tolerance: 5%; Voltage Rating: 50V; Component Kind: Standard; ComponentLink1Description: https://www.verical.com/server-webapp/html/getDatasheet?partID=33137&src-supplier=Verical; ComponentLink1URL: https://www.verical.com/server-webapp/html/getDatasheet?partID=33137&src-supplier=Verical; ComponentLink2Description: http://www.vishay.com/docs/20035/dcrcwe3.pdf?src-supplier=Avnet; ComponentLink2URL: http://www.vishay.com/docs/20035/dcrcwe3.pdf?src-supplier=Avnet; ComponentLink3Description: https://www.alliedelec.com/m/d/0c934d6939ccefa68dbe0b96d821c8cf.pdf?src-supplier=Allied+Electronics; ComponentLink3URL: https://www.alliedelec.com/m/d/0c934d6939ccefa68dbe0b96d821c8cf.pdf?src-supplier=Allied+Electronics; ComponentLink4Description: http://www.vishay.com/docs/20035/dcrcwe3.pdf?src-supplier=Digi-Key; ComponentLink4URL: http://www.vishay.com/docs/20035/dcrcwe3.pdf?src-supplier=Digi-Key; Composition: Thick Film; Contact Plating: Tin; Depth: 0.5mm; Features: Automotive AEC-Q200; Height: 0.35mm; Lead Free: Lead Free; Length: 1mm; Library Name: Miscellaneous Devices.IntLib; Max Operating Temperature: 155°C; Military Standard: Not; Min Operating Temperature: -55°C; Number of Pins: 2; Number of Terminations: 2; Package Quantity: 1; Packaging: Tape and Reel; Power Rating: 63mW; Radiation Hardening: No; REACH SVHC: Unknown; Stock 1: 0; Supplier 1: Arrow; Supplier Part Number 1: CRCW04023K30JNED; Termination: SMD/SMT; Voltage Rating (DC): 50V; Weight: 2.3E-05oz; Width: 0.5mm
R9 R17 = Res1: Thick Film Resistor -  2Kohm, 1%, 0402; Manufacturer: Vishay Semiconductors; Manufacturer Part Number: CRCW04022K00FKEDC; Power Rating: 62.5mW; Temperature Coefficient: 100ppm/°C; Component Kind: Standard; Composition: Thick Film; Contact Plating: Tin; Lead Free: Lead Free; Length: 1mm; Library Name: Miscellaneous Devices.IntLib; Max Operating Temperature: 155°C; Min Operating Temperature: -55°C; Mount: Surface Mount; Pricing 1: 1=0.12, 10=0.05, 50=0.05, 100=0.026, 1000=0.014, 10000=0.008 (USD); Stock 1: 219981; Supplier 1: Mouser; Supplier Part Number 1: 71-CRCW04022K00FKEDC; Termination: Wraparound; Tolerance: 1%
R11 R12 R16 = Res1: Thick Film Resistor -  1Kohm, 1%, 0402; Manufacturer: TE Connectivity; Manufacturer Part Number: CRGCQ0402F1K0; Max Power Dissipation: 63mW; Temperature Coefficient: 100ppm/°C; Case Code (Metric): 1005; Case/Package: 0402; Component Kind: Standard; ComponentLink10Description: https://4donline.ihs.com/images/VipMasterIC/IC/TYEL/TYEL-S-A0004929575/TYEL-S-A0004929575-1.pdf?hkey=52A5661711E402568146F3353EA87419&src-supplier=Farnell; ComponentLink10URL: https://4donline.ihs.com/images/VipMasterIC/IC/TYEL/TYEL-S-A0004929575/TYEL-S-A0004929575-1.pdf?hkey=52A5661711E402568146F3353EA87419&src-supplier=Farnell; ComponentLink11Description: https://4donline.ihs.com/images/VipMasterIC/IC/TYEL/TYEL-S-A0004929575/TYEL-S-A0004929575-1.pdf?hkey=52A5661711E402568146F3353EA87419&src-supplier=Newark; ComponentLink11URL: https://4donline.ihs.com/images/VipMasterIC/IC/TYEL/TYEL-S-A0004929575/TYEL-S-A0004929575-1.pdf?hkey=52A5661711E402568146F3353EA87419&src-supplier=Newark; ComponentLink1Description: http://uk.rs-online.com/webdocs/0d98/0900766b80d98824.pdf?src-supplier=RSComponents; ComponentLink1URL: http://uk.rs-online.com/webdocs/0d98/0900766b80d98824.pdf?src-supplier=RSComponents; ComponentLink2Description: http://www.vishay.com/docs/20035/dcrcwe3.pdf?src-supplier=Digi-Key; ComponentLink2URL: http://www.vishay.com/docs/20035/dcrcwe3.pdf?src-supplier=Digi-Key; ComponentLink3Description: http://www.farnell.com/datasheets/2045673.pdf?src-supplier=Element14; ComponentLink3URL: http://www.farnell.com/datasheets/2045673.pdf?src-supplier=Element14; ComponentLink4Description: http://www.vishay.com/docs/20035/dcrcwe3.pdf?src-supplier=Avnet; ComponentLink4URL: http://www.vishay.com/docs/20035/dcrcwe3.pdf?src-supplier=Avnet; ComponentLink5Description: https://www.distrelec.biz/Web/Downloads/a_/en/flD-CRCW-e3_data_en.pdf?mime=application%2Fpdf&src-supplier=Distrelec; ComponentLink5URL: https://www.distrelec.biz/Web/Downloads/a_/en/flD-CRCW-e3_data_en.pdf?mime=application%2Fpdf&src-supplier=Distrelec; ComponentLink6Description: http://www.vishay.com/docs/20035/dcrcwe3.pdf?src-supplier=Mouser; ComponentLink6URL: http://www.vishay.com/docs/20035/dcrcwe3.pdf?src-supplier=Mouser; ComponentLink7Description: http://www.farnell.com/datasheets/2111203.pdf?src-supplier=Element14; ComponentLink7URL: http://www.farnell.com/datasheets/2111203.pdf?src-supplier=Element14; ComponentLink8Description: http://www.vishay.com/docs/20035/dcrcwe3.pdf?src-supplier=Future+Electronics; ComponentLink8URL: http://www.vishay.com/docs/20035/dcrcwe3.pdf?src-supplier=Future+Electronics; ComponentLink9Description: https://www.te.com/commerce/DocumentDelivery/DDEController?Action=srchrtrv&amp;DocNm=1773204-3&amp;DocType=DS&amp;DocLang=English&src-supplier=Digi-Key; ComponentLink9URL: https://www.te.com/commerce/DocumentDelivery/DDEController?Action=srchrtrv&amp;DocNm=1773204-3&amp;DocType=DS&amp;DocLang=English&src-supplier=Digi-Key; Composition: Thick Film; Features: Moisture Resistant,Automotive AEC-Q200; Library Name: Miscellaneous Devices.IntLib; Max Operating Temperature: 155°C; Min Operating Temperature: -55°C; Number of Terminations: 2; Packaging: Tape and Reel; Pricing 1: 1=0.1, 10=0.022, 50=0.022, 100=0.009, 1000=0.004, 10000=0.002 (USD); Stock 1: 134807; Supplier 1: Mouser; Supplier Part Number 1: 279-CRGCQ0402F1K0; Tolerance: 1%
SW1 = SW PUSHBUTTON: Tactile Switch 3x4x2mm SMD 2-Pin; Manufacturer Part Number: 3x4x2mm switch; Case/Package: SMD; Component Kind: Standard
U1 = ESP8080_1: RF System on a Chip - SoC SIP module ESP32 with 4MByte Flash; Case/Package: QFN-48; Component Kind: Standard; Library Name: CUSTOM TNY290PG.SchLib; Manufacturer Part Number: ESP32-PICO-D4
U2 = GL823: USB 2.0 SD/MMC Card Reader Controller version A; Case/Package: QFN24; Component Kind: Standard; Library Name: CUSTOM TNY290PG.SchLib; Manufacturer Part Number: GL823 VERSION A; Value: -
U3 = 74ABT125PW_112: Buffers & Line Drivers QUAD BUFFER 3-S; Manufacturer: NXP Semiconductors; Manufacturer Part Number: 74ABT125PW,112; High Level Output Current: -32mA; Logic Function: Buffer,Inverting; Low Level Output Current: 64mA; Max Supply Voltage: 5.5V; Min Supply Voltage: 4.5V; Number of Bits per Element: 1; Number of Channels: 4; Operating Supply Voltage: 5V; Polarity: Non-Inverting; Propagation Delay: 4.6ns; Turn-On Delay Time: 6.8ns; Case/Package: TSSOP-14; Component Kind: Standard; ComponentLink1Description: https://www.verical.com/server-webapp/html/getDatasheet?partID=194630&src-supplier=Verical; ComponentLink1URL: https://www.verical.com/server-webapp/html/getDatasheet?partID=194630&src-supplier=Verical; ComponentLink2Description: http://www.nxp.com/documents/data_sheet/74ABT125.pdf?src-supplier=Future+Electronics; ComponentLink2URL: http://www.nxp.com/documents/data_sheet/74ABT125.pdf?src-supplier=Future+Electronics; Contact Plating: Gold; Lead Free: Lead Free; Library Name: CUSTOM TNY290PG.SchLib; Max Operating Temperature: 85°C; Min Operating Temperature: -40°C; Mount: Surface Mount; Number of Elements: 4; Number of Input Lines: 4; Number of Inputs: 4; Number of Output Lines: 4; Number of Outputs: 4; Number of Pins: 14; Package Quantity: 2400; Packaging: Rail/Tube; Pricing 1: 1=0.57, 10=0.472, 50=0.472, 100=0.304, 1000=0.244, 2500=0.206, 10000=0.198, 25000=0.19, 50000=0.187, 100000=0.183 (USD); Radiation Hardening: No; Stock 1: 2194; Supplier 1: Mouser
U4 U7 = LDO_5V_3V: LDO Voltage Regulators 600mA CMOS LDO 50mA 3.3V 250mV; Manufacturer: Diodes; Manufacturer Part Number: AP2112K-3.3TRG1; Accuracy: 1.5%; Max Input Voltage: 6V; Number of Outputs: 1; Output Type: Fixed; Polarity: Positive; Quiescent Current: 55uA; Value: LDO 5-3.3; Case/Package: SOT-23-5; Component Kind: Standard; ComponentLink1Description: http://www.diodes.com/datasheets/AP2112.pdf?src-supplier=Avnet; ComponentLink1URL: http://www.diodes.com/datasheets/AP2112.pdf?src-supplier=Avnet; ComponentLink2Description: https://www.diodes.com/assets/Datasheets/AP2112.pdf?src-supplier=Digi-Key; ComponentLink2URL: https://www.diodes.com/assets/Datasheets/AP2112.pdf?src-supplier=Digi-Key; Dropout Voltage: 250mV; Height: 1.3mm; Lead Free: Lead Free; Length: 3.1mm; Max Operating Temperature: 85°C; Max Output Current: 600mA; Min Operating Temperature: -40°C; Mount: Surface Mount; Number of Pins: 5; Number of Regulators: 1; Output Current: 600mA; Output Voltage: 3.3V; Package Quantity: 1; Packaging: Tape and Reel; Stock 1: 0; Supplier 1: Future Electronics; Supplier Part Number 1: 2098204; Width: 1.7mm; Library Name: VEGG_Schlib.SchLib
U5 = TPS2113ADRBR: Power Switch ICs -Autoswitching Pwr Mux 3-mm × 3-mm SON-8; Manufacturer: Texas Instruments; Current - Output 2: 1.25A; Max Input Voltage: 5.5V; Min Input Voltage: 2.8V; Number of Inputs: 2; Number of Outputs: 1; Turn-On Delay Time: 1ms; Case/Package: SON-8 (3X3); Category: Integrated Circuits (ICs); Component Kind: Standard; ComponentLink1Description: https://datasheet.ciiva.com/15109/getdatasheetpartid-627486-15109322.pdf?src-supplier=Verical; ComponentLink1URL: https://datasheet.ciiva.com/15109/getdatasheetpartid-627486-15109322.pdf?src-supplier=Verical; ComponentLink2Description: https://datasheet.ciiva.com/3232/tps2112a-3232522.pdf?src-supplier=Avnet; ComponentLink2URL: https://datasheet.ciiva.com/3232/tps2112a-3232522.pdf?src-supplier=Avnet; ComponentLink3Description: https://datasheet.ciiva.com/1961/tps2113a-1961004.pdf?src-supplier=Digi-Key; ComponentLink3URL: https://datasheet.ciiva.com/1961/tps2113a-1961004.pdf?src-supplier=Digi-Key; Lead Free: Lead Free; Library Name: CUSTOM TNY290PG.SchLib; Manufacturer Part Number: TPS2113ADRBR; Max Operating Temperature: 85°C; Max Output Current: 1.25A; Min Operating Temperature: -40°C; Number of Pins: 8; Operating Supply Voltage: 5.5V; Output Current: 1.25A; Package Quantity: 3000; Packaging: Tape and Reel; Pricing 1: 1=1.95000, 10=1.74900, 25=1.65000, 100=1.32000, 250=1.15500, 500=1.12200, 1000=0.89100 (USD); Radiation Hardening: No; RoHS: Yes; Stock 1: 3232; Supplier 1: Digi-Key; Supplier Part Number 1: 296-46373-1-ND
U6 = BQ24040DSQR: IC BATT CHG LI-ION 1 CELL 10SON; Manufacturer: Texas Instruments; Battery Pack Voltage: 4.2V; Fault Protection: Over Voltage,Over Temperature,Short Circuit; Max Charge Current: 1A; Max Power Dissipation: 1.66W; Max Supply Voltage: 28V; Min Input Voltage: 3.5V; Min Supply Voltage: 4.75V; Number of Cells: 1; Operating Supply Current: 800uA; Output Current: 800mA; Case/Package: WSON-10; Component Kind: Standard; ComponentLink1Description: http://www.ti.com/lit/pdf/slyt420?src-supplier=Digi-Key; ComponentLink1URL: http://www.ti.com/lit/pdf/slyt420?src-supplier=Digi-Key; ComponentLink2Description: http://www.ti.com/general/docs/suppproductinfo.tsp?distId=10&gotoUrl=http%3A%2F%2Fwww.ti.com%2Flit%2Fgpn%2Fbq24040&src-supplier=Digi-Key; ComponentLink2URL: http://www.ti.com/general/docs/suppproductinfo.tsp?distId=10&gotoUrl=http%3A%2F%2Fwww.ti.com%2Flit%2Fgpn%2Fbq24040&src-supplier=Digi-Key; ComponentLink3Description: http://datasheet.iiic.cc/texas_instruments/BQ24040DSQR.pdf?src-supplier=IIIC; ComponentLink3URL: http://datasheet.iiic.cc/texas_instruments/BQ24040DSQR.pdf?src-supplier=IIIC; Lead Free: Lead Free; Library Name: CUSTOM TNY290PG.SchLib; Manufacturer Part Number: BQ24040DSQR; Max Operating Temperature: 85°C; Min Operating Temperature: -40°C; Number of Pins: 10; Output Voltage: 4.2V; Package Quantity: 3000; Packaging: Tape and Reel; Pricing 1: 1=1.26, 10=1.124, 25=1.0672, 100=0.8194, 250=0.7241, 500=0.686, 1000=0.5335 (USD); REACH SVHC: No SVHC; Stock 1: 20950; Supplier 1: Digi-Key; Supplier Part Number 1: 296-37142-1-ND
